# Altium schematic sheet GasCap.Power.SchDoc
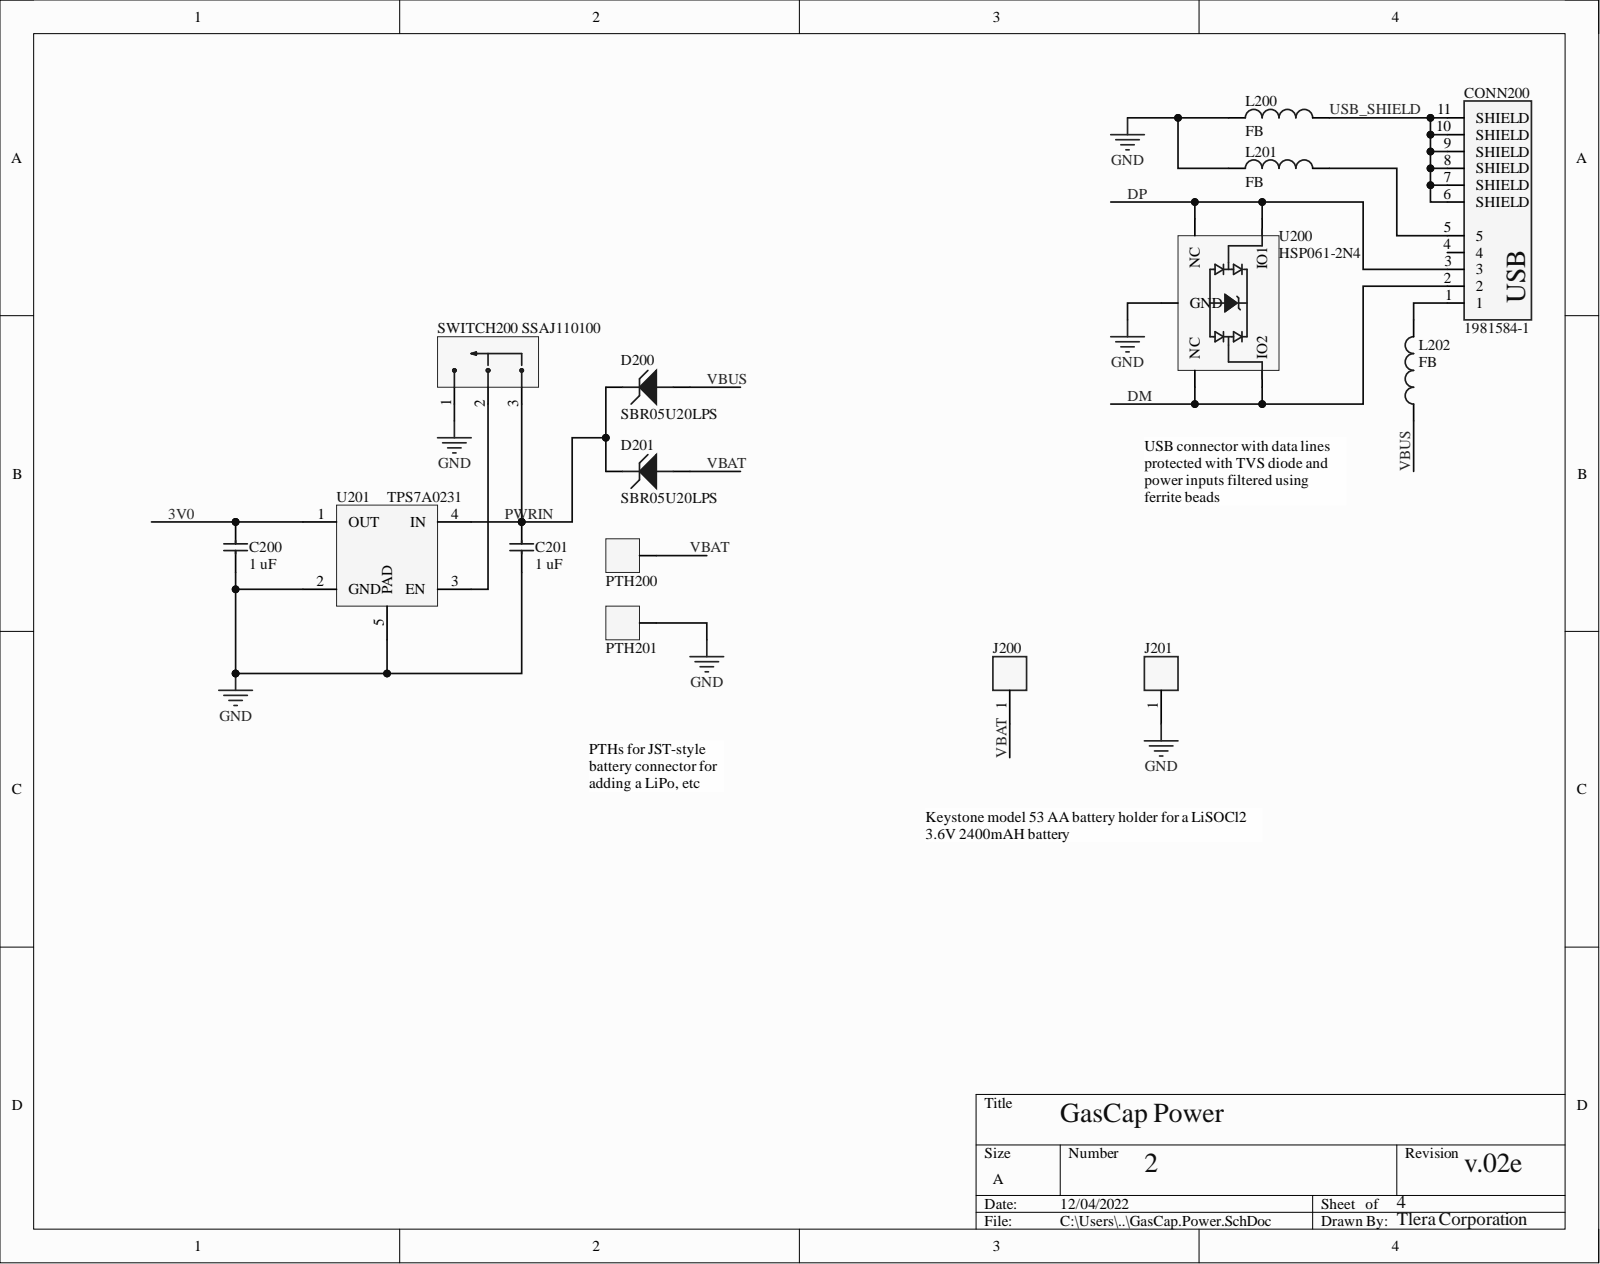
[diagram p0: GasCap.Power.SchDoc]

## Parts
C200  1 uF  [402Cap]
C201  1 uF  [402Cap]
CONN200  1981584-1  [TE_1981584-1]
D200  SBR05U20LPS  [SBR05U20LPS-7]
D201  SBR05U20LPS  [SBR05U20LPS-7]
J200  Keystone 53  [Keystone_53_0]  (value not drawn)
J201  Keystone 53  [Keystone_53_0]  (value not drawn)
L200  FB  [402IND]
L201  FB  [402IND]
L202  FB  [402IND]
PTH200  PTH_2mm  [PTH_2MM]  (value not drawn)
PTH201  PTH_2mm  [PTH_2MM]  (value not drawn)
SWITCH200  SSAJ110100  [SSAJ110100]
U200  HSP061-2N4  [HSP061-2N4]
U201  TPS7A0231  [TPS7A02]

## Nets
GND: C200.1 C201.1 J201.1 L200.1 L201.1 PTH201.1 SWITCH200.1 U200.5(GND) U201.2(GND) U201.5(PAD)
3V0: C200.2 U201.1(OUT)
DM: CONN200.2 U200.3(NC) U200.4(IO2)
DP: CONN200.3 U200.1(IO1) U200.2(NC)
PWRIN: C201.2 D200.1 D201.1 SWITCH200.3 U201.4(IN)
USB_SHIELD: CONN200.6(SHIELD) CONN200.7(SHIELD) CONN200.8(SHIELD) CONN200.9(SHIELD) CONN200.10(SHIELD) CONN200.11(SHIELD) L200.2
VBAT: D201.2 J200.1 PTH200.1
VBUS: D200.2 L202.1
NetCONN200_1: CONN200.1 L202.2
NetCONN200_5: CONN200.5 L201.2
NetSWITCH200_2: SWITCH200.2 U201.3(EN)

Not connected: CONN200 4

## Library data of the parts
C200 C201 = GRT155R61C105KE01D: 0402 1 uF Ceramic Capacitor, Multilayer, Ceramic, 16V, 10% +Tol, 10% -Tol, X5R, 15% TC, 1uF, Surface Mount, 0402; Manufacturer: Murata; Multilayer: Yes; Reference Standard: AEC-Q200; Capacitance: 1uF; Capacitor Type: Ceramic; Category: Ceramic Capacitors; Component Kind: Standard; ComponentLink1Description: https://datasheet.ciiva.com:443/pdfs/VipMasterIC/IC/MURE/MURE-S-A0004410517/MURE-S-A0004410643-1.pdf?src-supplier=IHS+Markit; ComponentLink1URL: https://datasheet.ciiva.com:443/pdfs/VipMasterIC/IC/MURE/MURE-S-A0004410517/MURE-S-A0004410643-1.pdf?src-supplier=IHS+Markit; ComponentLink2Description: http://search.murata.co.jp/Ceramy/image/img/A01X/G101/ENG/GRT155R61C105KE01-01.pdf?src-supplier=Digi-Key; ComponentLink2URL: http://search.murata.co.jp/Ceramy/image/img/A01X/G101/ENG/GRT155R61C105KE01-01.pdf?src-supplier=Digi-Key; Dielectric Material: Ceramic; JESD-609 Code: e3; Manufacturer Part Number: GRT155R61C105KE01D; Max Operating Temperature: 85°C; Min Operating Temperature: -55°C; Mount: Surface Mount; Negative Tolerance: 10%; Number of Pins: 2; Package Shape: Rectangular; Packaging: Tape and Reel; Positive Tolerance: 10%; Pricing 1: 1=0.12000, 10=0.08300, 50=0.04740, 100=0.03920, 500=0.02752, 1000=0.02278, 2500=0.02120, 5000=0.01939 (USD); Rated DC Voltage (URdc): 16V; REACH SVHC: Yes; RoHS: Yes; RoHS Compliant: Yes; Size Code: 0402; Stock 1: 691618; Supplier 1: Digi-Key; Supplier Part Number 1: 490-12255-1-ND; Temperature Characteristics Code: X5R; Terminal Finish: Nickel; Termination: Wraparound; Library Name: Gnat.SchLib
CONN200 = 1981584-1: Telecom and Datacom Connector, 5 Contact(s), Female, Right Angle, Surface Mount Terminal, Receptacle; Manufacturer: TE Connectivity; Manufacturer Part Number: 1981568-1; Centerline_Pitch: .65 mm[.025 in]; DIN Conformance: No; Number of Contacts: 5; Option: General Purpose; Availability: Unavailable; Body/Shell Style: RECEPTACLE; Category: Audio / Video Connectors; Component Kind: Standard; ComponentLink1Description: https://datasheet.ciiva.com:443/pdfs/VipMasterIC/IC/TYEL/TYEL-S-A0008869674/TYEL-S-A0008818286-1.pdf?src-supplier=IHS+Markit; ComponentLink1URL: https://datasheet.ciiva.com:443/pdfs/VipMasterIC/IC/TYEL/TYEL-S-A0008869674/TYEL-S-A0008818286-1.pdf?src-supplier=IHS+Markit; ComponentLink2Description: https://datasheet.ciiva.com/16290/1981568-1-16290215.pdf?src-supplier=Future+Electronics; ComponentLink2URL: https://datasheet.ciiva.com/16290/1981568-1-16290215.pdf?src-supplier=Future+Electronics; ComponentLink3Description: https://datasheet.ciiva.com/15170/getdatasheetpartid-241015-15170822.pdf?src-supplier=Verical; ComponentLink3URL: https://datasheet.ciiva.com/15170/getdatasheetpartid-241015-15170822.pdf?src-supplier=Verical; ComponentLink4Description: https://datasheet.ciiva.com/3138/eng-cs-1654270-2-0807-3138620.pdf?src-supplier=Avnet; ComponentLink4URL: https://datasheet.ciiva.com/3138/eng-cs-1654270-2-0807-3138620.pdf?src-supplier=Avnet; ComponentLink5Description: https://datasheet.ciiva.com/30216/eng-cs-1654270-2-0807-30216808.pdf?src-supplier=Onlinecomponents.com; ComponentLink5URL: https://datasheet.ciiva.com/30216/eng-cs-1654270-2-0807-30216808.pdf?src-supplier=Onlinecomponents.com; Connector Type: TELECOM AND DATACOM CONNECTOR; Contact Finish - Mating: NOT SPECIFIED; Contact Gender: Female; Empty Shell: No; Filter Feature: No; Flammability Rating: UL94 V-0; IEC Conformance: No; Insulation Material: Polyethylene; MF: TE Connectivity; MIL Conformance: No; Mixed Contacts: No; Mount: Board; Mounting Style: RIGHT ANGLE; MP: 1981584-1; Number_of_Positions: 5; Package: None; Price: None; Pricing 1: 1=1.71000, 10=1.55100, 25=1.45640, 50=1.39300, 100=1.32970, 250=1.20308, 500=1.10812 (USD); Product_Type: Connector; REACH SVHC: Yes; RoHS: Yes; RoHS Compliant: Yes; Shell Finish: Nickel; Shell Material: Stainless Steel; SnapEDA_Link: https://www.snapeda.com/parts/1981584-1/TE%20Connectivity%20AMP%20Connectors/view-part/454026/?ref=snap; Stock 1: 13156; Supplier 1: Digi-Key; Supplier Part Number 1: A97800DKR-ND; TE_purchase_URL: https://www.te.com/usa-en/product-1981584-1.html?te_bu=Cor&te_type=disp&te_campaign=seda_glo_cor-seda-global-disp-prtnr-fy19-seda-model-bom-cta_sma-317_1&elqCampaignId=32493; Termination: SMD/SMT; Library Name: Gnat.SchLib
D200 D201 = SBR05U20LPS: Rectifier Diode, 1 Phase, 1 Element, 0.5A, 20V V(RRM), Silicon; Manufacturer: Diodes; Forward Voltage-Max (VF): 280mV; Max Output Current: 500mA; Non-rep Pk Forward Current-Max: 6A; Number of Elements: 1; Package Body Material: Plastic; Qualification Status: Not Qualified; Time @ Peak Reflow Temperature-Max (s): 40s; Category: Rectifier Diodes; Component Kind: Standard; ComponentLink1Description: https://datasheet.ciiva.com:443/pdfs/VipMasterIC/IC/DIOD/DIOD-S-A0001756846/DIOD-S-A0001756846-1.pdf?src-supplier=IHS+Markit; ComponentLink1URL: https://datasheet.ciiva.com:443/pdfs/VipMasterIC/IC/DIOD/DIOD-S-A0001756846/DIOD-S-A0001756846-1.pdf?src-supplier=IHS+Markit; ComponentLink2Description: https://datasheet.ciiva.com/914/sbr05u20lps-914592.pdf?src-supplier=Digi-Key; ComponentLink2URL: https://datasheet.ciiva.com/914/sbr05u20lps-914592.pdf?src-supplier=Digi-Key; ComponentLink3Description: https://datasheet.ciiva.com/914/sbr05u20lps-914592.pdf?src-supplier=Avnet; ComponentLink3URL: https://datasheet.ciiva.com/914/sbr05u20lps-914592.pdf?src-supplier=Avnet; ComponentLink4Description: https://datasheet.ciiva.com/4715/sbr05u20lps-93753-4715541.pdf?src-supplier=Mouser; ComponentLink4URL: https://datasheet.ciiva.com/4715/sbr05u20lps-93753-4715541.pdf?src-supplier=Mouser; Diode Element Material: SILICON; Diode Type: RECTIFIER; JESD-609 Code: e4; Manufacturer Part Number: SBR05U20LPS-7; Max Operating Temperature: 150°C; Min Operating Temperature: -65°C; Moisture Sensitivity Level: 1; Number of Pins: 2; Package Shape: Rectangular; Package Style: CHIP CARRIERMeter; Peak Reflow Temperature (Cel): 260°C; Pricing 1: 1=0.58000, 10=0.49500, 100=0.36960, 500=0.29040, 1000=0.22440 (USD); REACH SVHC: Yes; Rep Pk Reverse Voltage-Max: 20V; RoHS: Yes; RoHS Compliant: Yes; Stock 1: 2013; Supplier 1: Digi-Key; Supplier Part Number 1: SBR05U20LPSDICT-ND; Surface Mount: Yes; Terminal Finish: Nickel; Terminal Form: No Lead; Terminal Position: BOTTOM; Library Name: Gnat.SchLib
J200 J201 = Conn_Keystone_53_eec: Batteries/Accessories; Manufacturer Part Number: 53; Category: Batteries and Accessories; Component Kind: Standard; ComponentLink1Description: Upverter; ComponentLink1URL: https://upverter.com/upn/revision/e1a39074cdc36335/; ComponentLink2Description: Datasheet; ComponentLink2URL: http://www.keyelco.com/product-pdf.cfm?p=811; ComponentLink3Description: https://datasheet.ciiva.com:443/pdfs/VipMasterIC/IC/KEYC/KEYC-S-A0001371036/KEYC-S-A0001371130-1.pdf?src-supplier=IHS+Markit; ComponentLink3URL: https://datasheet.ciiva.com:443/pdfs/VipMasterIC/IC/KEYC/KEYC-S-A0001371036/KEYC-S-A0001371130-1.pdf?src-supplier=IHS+Markit; ComponentLink4Description: http://www.farnell.com/datasheets/1703982.pdf?src-supplier=Farnell; ComponentLink4URL: http://www.farnell.com/datasheets/1703982.pdf?src-supplier=Farnell; ComponentLink5Description: http://www.farnell.com/datasheets/1703982.pdf?src-supplier=Newark; ComponentLink5URL: http://www.farnell.com/datasheets/1703982.pdf?src-supplier=Newark; ComponentLink6Description: http://keyelco.com/userAssets/file/M65p10.pdf?src-supplier=Digi-Key; ComponentLink6URL: http://keyelco.com/userAssets/file/M65p10.pdf?src-supplier=Digi-Key; Digikey Description: CONN BATTERY CLIP A/AA SMD; Digikey Part Number: 36-53-ND; helpURL: https://upverter.com/upn/revision/e1a39074cdc36335/; Lead Free: yes; Manufacturer: Keystone; Mouser Part Number: 534-53; Package: CONN_BAT; Part Number: 53; Pricing 1: 1=0.40000, 25=0.33800, 100=0.27970, 250=0.25768, 500=0.24214, 1000=0.22659, 2500=0.20588, 5000=0.19163 (USD); RoHS: TRUE; Stock 1: 138994; Supplier 1: Digi-Key; Supplier 2: Mouser; Supplier Part Number 1: 36-53-ND; Supplier Part Number 2: 534-53; Temperature: to; Library Name: GasCap.SchLib
L200-L202 = BLM15HD102SN1D: 0402 Ferrite Chip, 1 Function(s), 0.25A, 2 Pin(s); Manufacturer: Murata; Manufacturer Part Number: BLM15AX121SN1D; Current Rating: 700mA; DC Resistance-Max: 130mR; Max Frequency: 100MHz; Number of Functions: 1; Output Impedance: 120R; Case Code: 0402; Category: Inductors; Component Kind: Standard; ComponentLink1Description: https://datasheet.ciiva.com:443/pdfs/VipMasterIC/IC/MURE/MURE-S-A0010009827/MURE-S-A0010009827-1.pdf?src-supplier=IHS+Markit; ComponentLink1URL: https://datasheet.ciiva.com:443/pdfs/VipMasterIC/IC/MURE/MURE-S-A0010009827/MURE-S-A0010009827-1.pdf?src-supplier=IHS+Markit; ComponentLink2Description: https://datasheet.ciiva.com/41449/001172167-da-01-en-drossel-0402-120r-1-0x0-5mm-10000st-41449456.pdf?src-supplier=Conrad; ComponentLink2URL: https://datasheet.ciiva.com/41449/001172167-da-01-en-drossel-0402-120r-1-0x0-5mm-10000st-41449456.pdf?src-supplier=Conrad; ComponentLink3Description: https://datasheet.ciiva.com/1012/l0110e-1012387.pdf?src-supplier=Digi-Key; ComponentLink3URL: https://datasheet.ciiva.com/1012/l0110e-1012387.pdf?src-supplier=Digi-Key; ComponentLink4Description: https://datasheet.ciiva.com/1012/l0110e-1012386.pdf?src-supplier=Digi-Key; ComponentLink4URL: https://datasheet.ciiva.com/1012/l0110e-1012386.pdf?src-supplier=Digi-Key; ComponentLink5Description: https://datasheet.ciiva.com/1012/l0110e-1012388.pdf?src-supplier=Digi-Key; ComponentLink5URL: https://datasheet.ciiva.com/1012/l0110e-1012388.pdf?src-supplier=Digi-Key; ComponentLink6Description: https://datasheet.ciiva.com:443/pdfs/VipMasterIC/IC/MURE/MURE-S-A0008871331/MURE-S-A0008871390-1.pdf?src-supplier=IHS+Markit; ComponentLink6URL: https://datasheet.ciiva.com:443/pdfs/VipMasterIC/IC/MURE/MURE-S-A0008871331/MURE-S-A0008871390-1.pdf?src-supplier=IHS+Markit; ComponentLink7Description: https://datasheet.ciiva.com/27054/1844707-27054220.pdf?src-supplier=Jameco; ComponentLink7URL: https://datasheet.ciiva.com/27054/1844707-27054220.pdf?src-supplier=Jameco; ComponentLink8Description: https://datasheet.ciiva.com/11799/0900766b811b5866-11799124.pdf?src-supplier=RSComponents; ComponentLink8URL: https://datasheet.ciiva.com/11799/0900766b811b5866-11799124.pdf?src-supplier=RSComponents; ComponentLink9Description: https://datasheet.ciiva.com/18089/getdatasheetpartid-153027-18089625.pdf?src-supplier=Verical; ComponentLink9URL: https://datasheet.ciiva.com/18089/getdatasheetpartid-153027-18089625.pdf?src-supplier=Verical; ComponentLink10Description: https://datasheet.ciiva.com/41425/001171683-da-01-en-chip-drossel0402-1000r-250ma-1-0x0-5mm-41425767.pdf?src-supplier=Conrad; ComponentLink10URL: https://datasheet.ciiva.com/41425/001171683-da-01-en-chip-drossel0402-1000r-250ma-1-0x0-5mm-41425767.pdf?src-supplier=Conrad; ComponentLink11Description: https://datasheet.ciiva.com/41449/001172200-da-01-en-drossel-0402-1000r-21-0x0-5mm-10000st-41449488.pdf?src-supplier=Conrad; ComponentLink11URL: https://datasheet.ciiva.com/41449/001172200-da-01-en-drossel-0402-1000r-21-0x0-5mm-10000st-41449488.pdf?src-supplier=Conrad; ComponentLink12Description: https://datasheet.ciiva.com/1466/5168-1466860.pdf?src-supplier=Element14; ComponentLink12URL: https://datasheet.ciiva.com/1466/5168-1466860.pdf?src-supplier=Element14; ComponentLink13Description: https://datasheet.ciiva.com/1466/5168-1466859.pdf?src-supplier=Element14; ComponentLink13URL: https://datasheet.ciiva.com/1466/5168-1466859.pdf?src-supplier=Element14; ComponentLink14Description: https://datasheet.ciiva.com/1012/l0110s0101blm15h-1012795.pdf?src-supplier=Digi-Key; ComponentLink14URL: https://datasheet.ciiva.com/1012/l0110s0101blm15h-1012795.pdf?src-supplier=Digi-Key; ComponentLink15Description: https://datasheet.ciiva.com/1012/l0110s0101blm15h-1012794.pdf?src-supplier=Digi-Key; ComponentLink15URL: https://datasheet.ciiva.com/1012/l0110s0101blm15h-1012794.pdf?src-supplier=Digi-Key; ComponentLink16Description: https://datasheet.ciiva.com/1012/l0110s0101blm15h-1012796.pdf?src-supplier=Digi-Key; ComponentLink16URL: https://datasheet.ciiva.com/1012/l0110s0101blm15h-1012796.pdf?src-supplier=Digi-Key; ComponentLink17Description: https://datasheet.ciiva.com/3081/l0110s0101blm15h-3081604.pdf?src-supplier=Avnet; ComponentLink17URL: https://datasheet.ciiva.com/3081/l0110s0101blm15h-3081604.pdf?src-supplier=Avnet; ComponentLink18Description: https://datasheet.ciiva.com/16373/l0110s0101blm15h-16373866.pdf?src-supplier=Future+Electronics; ComponentLink18URL: https://datasheet.ciiva.com/16373/l0110s0101blm15h-16373866.pdf?src-supplier=Future+Electronics; ComponentLink19Description: https://datasheet.ciiva.com/3081/l0110s0101blm15h-3081605.pdf?src-supplier=Avnet; ComponentLink19URL: https://datasheet.ciiva.com/3081/l0110s0101blm15h-3081605.pdf?src-supplier=Avnet; Construction: Chip Bead; Filter Type: FERRITE CHIP; Height: 0.5mm; JESD-609 Code: e3; Length: 1mm; Material: Ferrite; Max Operating Temperature: 125°C; Min Frequency: 100MHz; Min Operating Temperature: -55°C; Mount: Surface Mount; Packaging: Tape and Reel; Pricing 1: 1=0.10000, 10=0.06700, 25=0.06040, 50=0.05440, 100=0.03990, 250=0.02904, 500=0.02662, 1000=0.02299, 5000=0.01815 (USD); Pricing 2: 1=0.14000, 10=0.11200, 25=0.08680, 50=0.07180, 100=0.06200, 250=0.04956, 500=0.04460, 1000=0.03717, 5000=0.03469 (USD); REACH SVHC: Yes; RoHS: Yes; RoHS Compliant: Yes; Stock 1: 46609; Stock 2: 408631; Supplier 1: Digi-Key; Supplier 2: Digi-Key; Supplier Part Number 1: 490-5439-1-ND; Supplier Part Number 2: 490-4003-1-ND; Terminal Finish: Tin; Width: 0.5mm
  L200: Library Name: Gnat.SchLib
  L201 L202: Library Name: GasCap.SchLib
SWITCH200 = SSAJ110100: Slide Switch, SPDT, Latched, 0.01A, 5VDC, Solder Terminal, Surface Mount-right Angle; Manufacturer: Alps Electric; Body Breadth: 2.5inch; Body Height: 0.7mm; Body Length or Diameter: 5.5mm; Contact Current(DC)-Max: 10mA; Contact DC Max Rating R Load: .01A@5VDC; Contact Voltage(DC)-Max: 5V; Electrical Life: 10000Cycles; Operating Force-Max: 1.5N; Category: Uncategorized; Component Kind: Standard; ComponentLink1Description: https://datasheet.ciiva.com:443/pdfs/VipMasterIC/IC/ALPS/ALPS-S-A0002903997/ALPS-S-A0002903889-1.pdf?src-supplier=IHS+Markit; ComponentLink1URL: https://datasheet.ciiva.com:443/pdfs/VipMasterIC/IC/ALPS/ALPS-S-A0002903997/ALPS-S-A0002903889-1.pdf?src-supplier=IHS+Markit; ComponentLink2Description: https://datasheet.ciiva.com/26906/getdatasheetpartid-652595-26906470.pdf?src-supplier=Verical; ComponentLink2URL: https://datasheet.ciiva.com/26906/getdatasheetpartid-652595-26906470.pdf?src-supplier=Verical; Manufacturer Part Number: SSAJ110100; Max Operating Temperature: 60°C; Min Operating Temperature: -10°C; Mount: Surface Mount; Packaging: Tape and Reel; Pricing 1: 1=1.74000, 10=1.57000, 50=1.41000, 100=1.35000, 1000=0.93800, 10000=0.85300 (USD); REACH SVHC: No SVHC; RoHS: Yes; RoHS Compliant: Yes; Stock 1: 12260; Supplier 1: Mouser; Supplier Part Number 1: 688-SSAJ110100; Switch Action: LATCHED; Switch Function: SPDT; Switch Type: Slide Switch; Termination: Solder; Library Name: GasCap.SchLib
U200 = HSP061-2N4: Trans Voltage Suppressor Diode, Unidirectional, 1 Element, Silicon; Manufacturer: STMicroelectronics; Min Breakdown Voltage: 6V; Number of Elements: 1; Package Body Material: Plastic; Category: TVS Diodes; Component Kind: Standard; ComponentLink1Description: https://datasheet.ciiva.com:443/pdfs/VipMasterIC/IC/SGST/SGST-S-A0001367420/SGST-S-A0001367420-1.pdf?src-supplier=IHS+Markit; ComponentLink1URL: https://datasheet.ciiva.com:443/pdfs/VipMasterIC/IC/SGST/SGST-S-A0001367420/SGST-S-A0001367420-1.pdf?src-supplier=IHS+Markit; ComponentLink2Description: https://datasheet.ciiva.com/16207/dm00047940-16207981.pdf?src-supplier=Future+Electronics; ComponentLink2URL: https://datasheet.ciiva.com/16207/dm00047940-16207981.pdf?src-supplier=Future+Electronics; ComponentLink3Description: https://datasheet.ciiva.com/6574/dm00047940-6574581.pdf?src-supplier=Digi-Key; ComponentLink3URL: https://datasheet.ciiva.com/6574/dm00047940-6574581.pdf?src-supplier=Digi-Key; ComponentLink4Description: https://datasheet.ciiva.com/22685/1837089-22685815.pdf?src-supplier=Element14; ComponentLink4URL: https://datasheet.ciiva.com/22685/1837089-22685815.pdf?src-supplier=Element14; Diode Element Material: SILICON; Diode Type: TRANS VOLTAGE SUPPRESSOR; Manufacturer Part Number: HSP061-2N4; Max Operating Temperature: 150°C; Min Operating Temperature: -40°C; Number of Pins: 4; Package Shape: Rectangular; Package Style: SMALL OUTLINEMeter; Polarity: Unidirectional; Pricing 1: 1=0.77000, 10=0.67800, 50=0.67800, 100=0.52000, 1000=0.32900, 10000=0.30900 (USD); REACH SVHC: Yes; RoHS: Yes; RoHS Compliant: Yes; Stock 1: 20375; Supplier 1: Mouser; Supplier Part Number 1: 511-HSP061-2N4; Surface Mount: Yes; Technology: AVALANCHE; Terminal Form: No Lead; Terminal Position: DUAL; Library Name: Gnat.SchLib
U201 = TPS7A0231: Fixed Positive LDO Regulator, 3.1V, 0.36V Dropout, PDSO4; Manufacturer: Texas Instruments; Manufacturer Part Number: TPS7A0230PDQNR; Category: Voltage Regulators - Linear; Component Kind: Standard; ComponentLink1Description: https://www.ti.com:443/lit/ds/symlink/tps7a02.pdf?src-supplier=IHS+Markit; ComponentLink1URL: https://www.ti.com:443/lit/ds/symlink/tps7a02.pdf?src-supplier=IHS+Markit; Pricing 1: 1=1.58000, 10=1.41700, 25=1.34440, 100=1.10440, 250=1.03240, 500=0.91234, 1000=0.79275 (USD); Pricing 2: 3000=0.79275 (USD); Pricing 3: 1=1.58000, 10=1.42000, 25=1.35000, 50=1.35000, 100=1.11000, 250=1.04000, 500=0.91300, 1000=0.72100, 3000=0.69600, 10000=0.69600 (USD); Pricing 4: 1=1.58000, 10=1.41700, 25=1.34440, 100=1.10440, 250=1.03240, 500=0.91234, 1000=0.72480 (USD); RoHS: Yes; Stock 2: 3000; Stock 3: 1895; Stock 4: 1297; Supplier 1: Digi-Key; Supplier 2: Digi-Key; Supplier 3: Mouser; Supplier 4: Digi-Key; Supplier Part Number 1: 296-TPS7A0230PDQNRCT-ND; Supplier Part Number 2: 296-TPS7A0233DQNRTR-ND; Supplier Part Number 3: 595-TPS7A0233DQNR; Supplier Part Number 4: 296-TPS7A0231PDQNRCT-ND; Library Name: Gnat.SchLib
PTH200 PTH201 = PTH_2mm: Component Kind: Standard; Library Name: Gnat.SchLib
